# Altium schematic sheet Sheet1.SchDoc
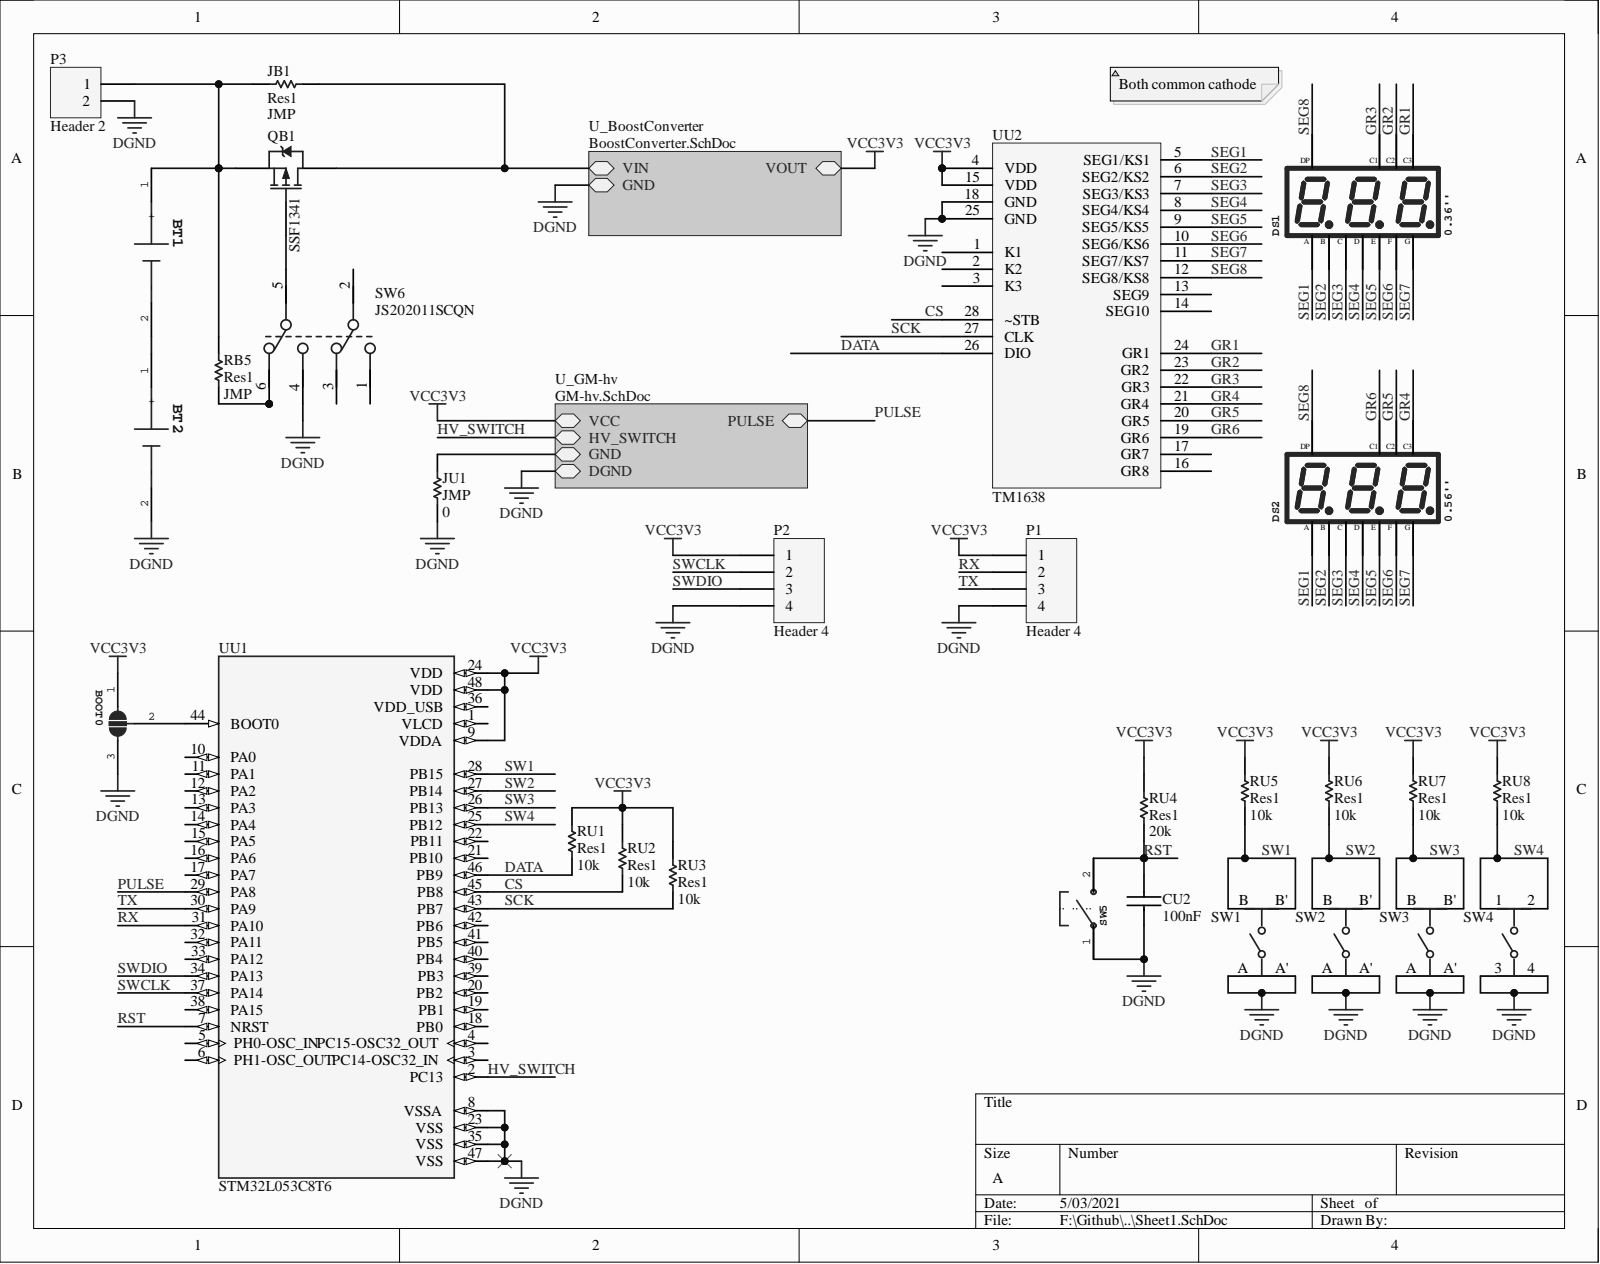
[diagram p0: Sheet1.SchDoc]

## Parts
BOOT0  2-Way Solder Jumper  [SOLDERJUMPER_2WAY_OPEN_NOPASTE]  (value not drawn)
BT1  Holder Cr2032 Gold Leads Smd  [AAA holder smd]  (value not drawn)
BT2  Holder Cr2032 Gold Leads Smd  [AAA holder smd]  (value not drawn)
CU2  100nF  [CAP0603R]
DS1  0.36''  [7-seg 3bit 0.36]
DS2  0.56''  [BA56-12EWA]
JB1  Res1  value JMP  [RES0603R]
JU1  JMP  value 0  [RES0603R]
P1  Header 4  [HDR1X4]
P2  Header 4  [HDR1X4]
P3  Header 2  [HDR1X2]
QB1  SSF1341  [SOT23]
RB5  Res1  value JMP  [RES0603R]
RU1  Res1  value 10k  [RES0603R]
RU2  Res1  value 10k  [RES0603R]
RU3  Res1  value 10k  [RES0603R]
RU4  Res1  value 20k  [RES0603R]
RU5  Res1  value 10k  [RES0603R]
RU6  Res1  value 10k  [RES0603R]
RU7  Res1  value 10k  [RES0603R]
RU8  Res1  value 10k  [RES0603R]
SW1  EVQ-PAE05R  [FP-EVQ-PAE05R-MFG]  (value not drawn)
SW2  EVQ-PAE05R  [FP-EVQ-PAE05R-MFG]  (value not drawn)
SW3  EVQ-PAE05R  [FP-EVQ-PAE05R-MFG]  (value not drawn)
SW4  FSM100  [FP-FSM100-MFG]  (value not drawn)
SW5  Switch  [PUSH_SMD_3x4]  (value not drawn)
SW6  JS202011SCQN  [JS202011SCQN]
UU1  STM32L053C8T6  [LQFP48_7X7MM_0.5MMPP]
UU2  TM1638  [SOP-28]

## Nets
DGND: BOOT0.3 BT2.2 CU2.2 JU1.1 P1.4 P2.4 P3.2 SW1.A SW1.A' SW2.A SW2.A' SW3.A SW3.A' SW4.3 SW4.4 SW5.1 SW6.4 UU1.8(VSSA) UU1.23(VSS) UU1.35(VSS) UU1.47(VSS) UU2.18(GND) UU2.25(GND)
VCC3V3: BOOT0.1 P1.1 P2.1 RU1.2 RU2.1 RU3.1 RU4.1 RU5.2 RU6.2 RU7.2 RU8.2 UU1.9(VDDA) UU1.24(VDD) UU1.48(VDD) UU2.4(VDD) UU2.15(VDD)
CS: RU2.2 UU1.45(PB8) UU2.28(~STB)
DATA: RU1.1 UU1.46(PB9) UU2.26(DIO)
GR1: DS1.12 UU2.24(GR1)
GR2: DS1.9 UU2.23(GR2)
GR3: DS1.8 UU2.22(GR3)
GR4: DS2.12 UU2.21(GR4)
GR5: DS2.9 UU2.20(GR5)
GR6: DS2.8 UU2.19(GR6)
HV_SWITCH: UU1.2(PC13)
PULSE: UU1.29(PA8)
RST: CU2.1 RU4.2 SW5.2 UU1.7(NRST)
RX: P1.2 UU1.31(PA10)
SCK: RU3.2 UU1.43(PB7) UU2.27(CLK)
SEG1: DS1.11 DS2.11 UU2.5(SEG1/KS1)
SEG2: DS1.7 DS2.7 UU2.6(SEG2/KS2)
SEG3: DS1.4 DS2.4 UU2.7(SEG3/KS3)
SEG4: DS1.2 DS2.2 UU2.8(SEG4/KS4)
SEG5: DS1.1 DS2.1 UU2.9(SEG5/KS5)
SEG6: DS1.10 DS2.10 UU2.10(SEG6/KS6)
SEG7: DS1.5 DS2.5 UU2.11(SEG7/KS7)
SEG8: DS1.3 DS2.3 UU2.12(SEG8/KS8)
SW1: RU5.1 SW1.B SW1.B' UU1.28(PB15)
SW2: RU6.1 SW2.B SW2.B' UU1.27(PB14)
SW3: RU7.1 SW3.B SW3.B' UU1.26(PB13)
SW4: RU8.1 SW4.1 SW4.2 UU1.25(PB12)
SWCLK: P2.2 UU1.37(PA14)
SWDIO: P2.3 UU1.34(PA13)
TX: P1.3 UU1.30(PA9)
NetBOOT0_2: BOOT0.2 UU1.44(BOOT0)
NetBT1_1: BT1.1 JB1.2 P3.1 QB1.2 RB5.1
NetBT1_2: BT1.2 BT2.1
NetJB1_1: JB1.1 QB1.3
NetJU1_2: JU1.2
NetQB1_1: QB1.1 SW6.5
NetRB5_2: RB5.2 SW6.6
NetSW6_1: SW6.1
NetSW6_2: SW6.2
NetSW6_3: SW6.3
NetUU1_1: UU1.1(VLCD)
NetUU1_3: UU1.3(PC14-OSC32_IN)
NetUU1_4: UU1.4(PC15-OSC32_OUT)
NetUU1_5: UU1.5(PH0-OSC_IN)
NetUU1_6: UU1.6(PH1-OSC_OUT)
NetUU1_10: UU1.10(PA0)
NetUU1_11: UU1.11(PA1)
NetUU1_12: UU1.12(PA2)
NetUU1_13: UU1.13(PA3)
NetUU1_14: UU1.14(PA4)
NetUU1_15: UU1.15(PA5)
NetUU1_16: UU1.16(PA6)
NetUU1_17: UU1.17(PA7)
NetUU1_18: UU1.18(PB0)
NetUU1_19: UU1.19(PB1)
NetUU1_20: UU1.20(PB2)
NetUU1_21: UU1.21(PB10)
NetUU1_22: UU1.22(PB11)
NetUU1_32: UU1.32(PA11)
NetUU1_33: UU1.33(PA12)
NetUU1_36: UU1.36(VDD_USB)
NetUU1_38: UU1.38(PA15)
NetUU1_39: UU1.39(PB3)
NetUU1_40: UU1.40(PB4)
NetUU1_41: UU1.41(PB5)
NetUU1_42: UU1.42(PB6)
NetUU2_1: UU2.1(K1)
NetUU2_2: UU2.2(K2)
NetUU2_3: UU2.3(K3)
NetUU2_13: UU2.13(SEG9)
NetUU2_14: UU2.14(SEG10)
NetUU2_16: UU2.16(GR8)
NetUU2_17: UU2.17(GR7)

## Library data of the parts
BOOT0 = SOLDERJUMPER_2WAY0603: Component Kind: Standard; Library Name: Standard2.SchLib
BT1 BT2 = BU2032SM-BT-GTR: MF: MPD; Availability: Good; Component Kind: Standard; Library Name: Battery Holders.SchLib; MP: BU2032SM-BT-GTR; Package: None; Price: 0.64 USD
CU2 = Capacitor: Component Kind: Standard; Library Name: Standard.SchLib
DS1 DS2 = BA56-12EWA: MF: Kingbright; Availability: Good; Component Kind: Standard; Library Name: LEDs.SchLib; MP: BA56-12EWA; Package: DIP-12 Kingbright; Price: 2.42 USD
JB1 = Res1: Resistor; Value: JMP; Component Kind: Standard; LatestRevisionDate: 17-Jul-2002; LatestRevisionNote: Re-released for DXP Platform.; Library Name: Miscellaneous Devices.IntLib; PackageDescription: Axial Device, Thru-Hole; 2 Leads; 0.3 in Pin Spacing; PackageReference: AXIAL-0.3; Published: 8-Jun-2000; Signal Integrity: Res; Simulation: RESISTOR; Publisher: Altium Limited
JU1 RB5 RU1-RU8 = Res1: Resistor; Component Kind: Standard; LatestRevisionDate: 17-Jul-2002; LatestRevisionNote: Re-released for DXP Platform.; PackageDescription: Axial Device, Thru-Hole; 2 Leads; 0.3 in Pin Spacing; PackageReference: AXIAL-0.3; Published: 8-Jun-2000; Signal Integrity: Res; Simulation: RESISTOR; Publisher: Altium Limited
  JU1 RB5 RU1-RU4: Library Name: Miscellaneous Devices.IntLib
  RU5-RU8: Library Name: Standard.SchLib
P1 P2 = Header 4: Header, 4-Pin; Component Kind: Standard; LatestRevisionDate: 17-Jul-2002; LatestRevisionNote: Re-released for DXP Platform.; Library Name: Miscellaneous Connectors.IntLib; Signal Integrity: Connector; Publisher: Altium Limited
P3 = Header 2: Header, 2-Pin; Component Kind: Standard; LatestRevisionDate: 17-Jul-2002; LatestRevisionNote: Re-released for DXP Platform.; Library Name: Miscellaneous Connectors.IntLib; Signal Integrity: Connector; Publisher: Altium Limited
QB1 = PMOS: P-Channel Power MOSFET PMV65XP; Part Number: 1758119; Component Kind: Standard; ComponentLink1Description: Datasheet; ComponentLink1URL: http://www.farnell.com/datasheets/1719226.pdf; Library Name: Standard.SchLib; Package: SOT23; Supplier: Farnell
SW1-SW3 = CMP-05618-000051-1: SWITCH TACTILE SPST-NO 0.02A 15V; Actuator Color: Red; Actuator Length: 1.1mm; Actuator Type: Standard; Contact Current Rating: 20mA; Contact Resistance: 50mR; Electrical Life: 100000Cycles; Illumination Type: Non-Illuminated; Operating Force: 1.6N; Switch Function: Off-Mom; Switch Travel: 0.25mm; Throw Configuration: SPST; Color: Red; Component Kind: Standard; Contact Plating: Gold; Current Rating: 20mA; Depth: 7.8mm; Height: 5mm; Lead Free: Lead Free; Length: 6mm; Library Name: Altium Content Vault; Max Current Rating: 20mA; Max Operating Temperature: 70°C; Max Voltage Rating (DC): 15V; Mechanical Life: 100000Cycles; Min Operating Temperature: -20°C; Mount: Through Hole; Orientation: Straight; Package Quantity: 500; Packaging: Bulk; Radiation Hardening: No; Series: EVQPA; Size: 6mm; Termination: PC Pin; Voltage Rating (DC): 15V; Width: 6mm
SW4 = CMP-03402-000014-1: SWITCH TACTILE SPST-NO 0.05A 24V; Actuator Color: Black; Actuator Length: 6.35mm; Actuator Material: Polyester; Actuator Type: Standard; Contact Current Rating: 50mA; Contact Resistance: 100mR; Electrical Life: 100000Cycles; Illumination Type: Non-Illuminated; Max Voltage Rating (DC): 12V; Operating Force: 1.57N; Switch Function: Off-Mom; Switch Travel: 0.3mm; Throw Configuration: SPST; Component Kind: Standard; Contact Material: Copper Alloy; Contact Plating: Silver; Contact Type: 1 maker; Current Rating: 50mA; Depth: 12.5mm; ELV: Compliant; Lead Free: Lead Free; Length: 12mm; Library Name: Altium Content Vault; Max Current Rating: 50mA; Max Operating Temperature: 85°C; Mechanical Life: 100000Cycles; Min Operating Temperature: -35°C; Mount: Through Hole; Orientation: Straight; Package Quantity: 400; Packaging: Loose Piece; RoHS Compliant: Yes; Series: FSM100 ~ 104; Termination: PC Pins; Type: Switch; Voltage Rating (DC): 24V
SW5 = B3U-1000P: MANUFACTURER: Omron; Component Kind: Standard; DIGIKEY: SW1020CT-ND; Library Name: Switches.SchLib; MPN: B3U-1000P
SW6 = JS202011SCQN: SWITCH SLIDE DPDT 300MA 6V; Actuator Length: 2mm; Actuator Material: Nylon; Actuator Type: Standard; Contact Resistance: 70mR; Electrical Life: 5000Cycles; Switch Function: On-On; Component Kind: Standard; Contact Current Rating: 300mA; Contact Material: Copper Alloy; Contact Plating: Silver; Current Rating: 300mA; Depth: 7.5mm; Flammability Rating: UL94 V-2; Housing Material: Nylon; Lead Free: Lead Free; Length: 9mm; Library Name: Switches.SchLib; Max Current Rating: 300mA; Max Operating Temperature: 85°C; Max Voltage Rating (DC): 6V; Min Operating Temperature: -20°C; Mount: Surface Mount; Orientation: Vertical; Package Quantity: 1; Packaging: Tape and Reel; Radiation Hardening: No; REACH SVHC: No SVHC; Switch Travel: 2mm; Termination: Gull Wing; Throw Configuration: DPDT; Voltage Rating (DC): 6V
UU1 = STM32L053C8T6: ARMÂ® CortexÂ®-M0+ STM32L0 Microcontroller IC 32-Bit 32MHz 64KB _64K x 8_ FLASH 48-LQFP _7x7_; MF: STMicroelectronics; Component Kind: Standard; Digi-Key_Part_Number: 497-14906-ND; Digi-Key_Purchase_URL: https://www.digikey.nl/product-detail/en/stmicroelectronics/STM32L053C8T6/497-14906-ND/4935255?utm_source=snapeda&utm_medium=aggregator&utm_campaign=symbol; Library Name: STM.SchLib; MP: STM32L053C8T6; Package: LQFP-48 STMicroelectronics; SnapEDA_Link: https://www.snapeda.com/parts/STM32L053C8T6/STMicroelectronics/view-part/552329/?ref=snap
UU2 = TM1638: 7Seg and keyboard driver; Component Kind: Standard; Library Name: IC.SchLib
